# Altium schematic sheet Module.SchDoc(Module)
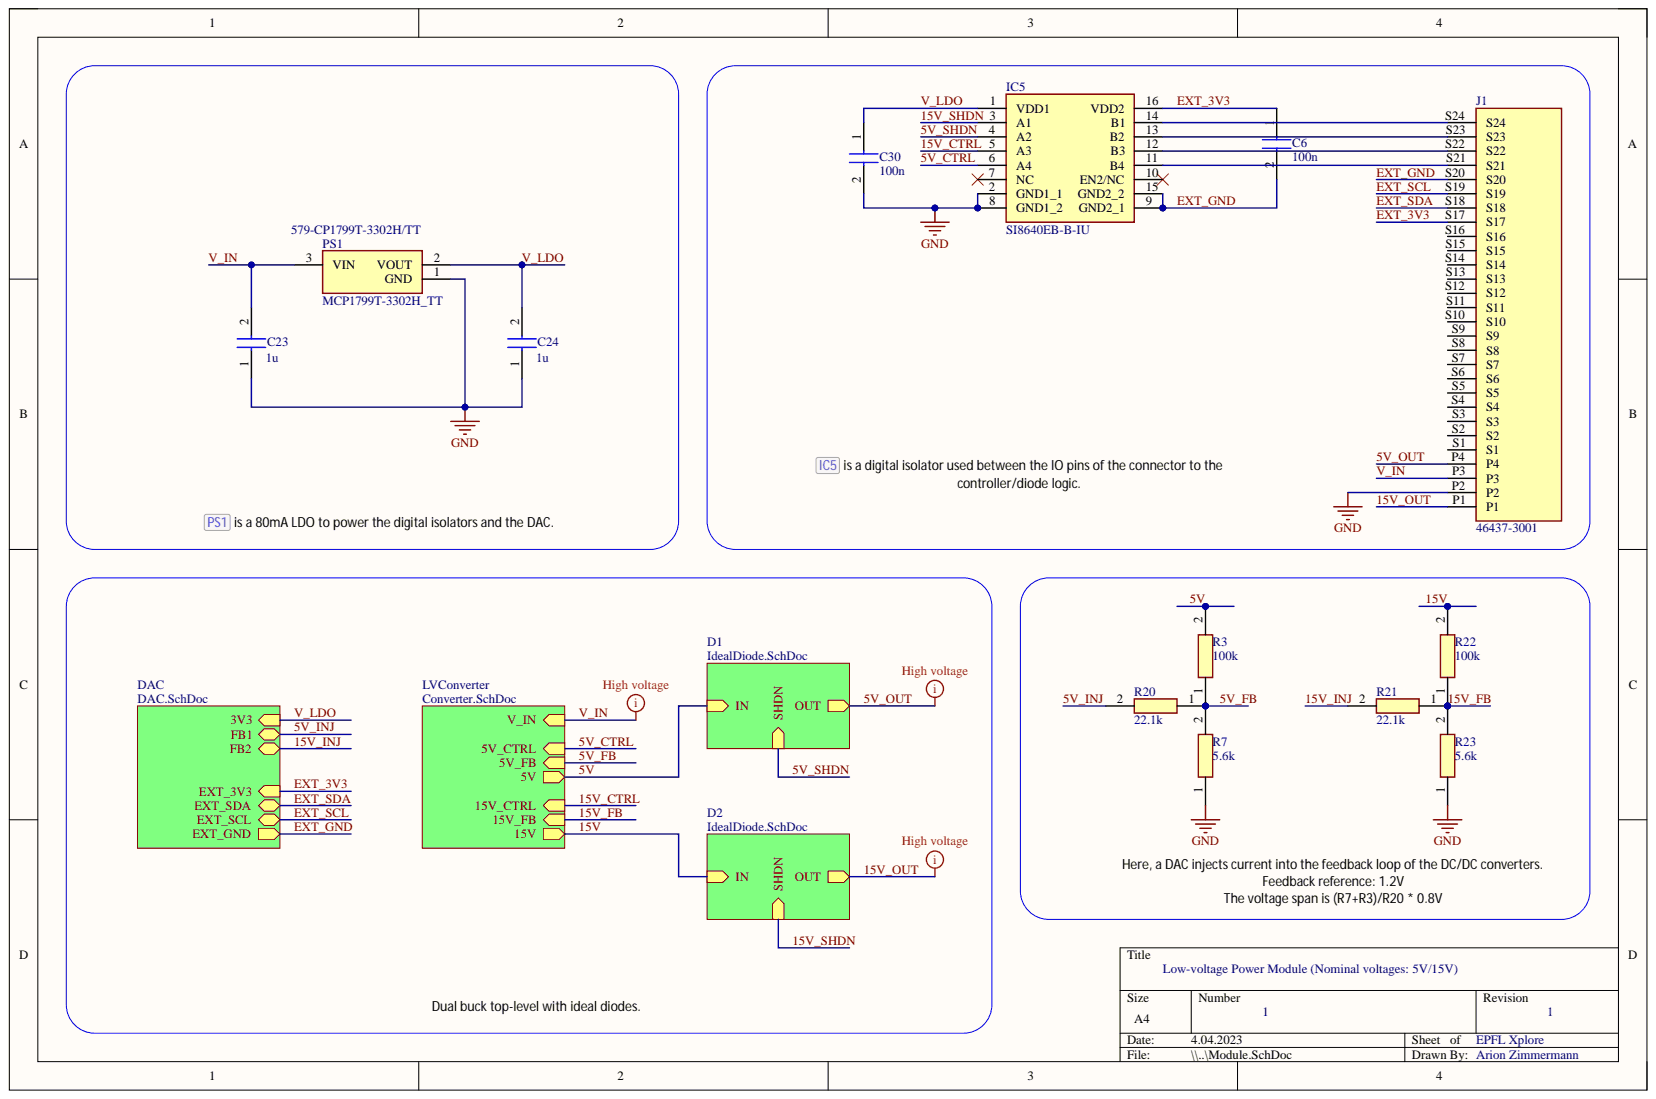
[diagram p0: Module.SchDoc]

## Parts
C6  value 100n  [CAPC1608X95N]  (hidden comment: C0603C104K5RACTU)
C23  value 1u  [CAPC3216X110N]  (hidden comment: C1206C105K5RAC)
C24  value 1u  [CAPC3216X110N]  (hidden comment: C1206C105K5RAC)
C30  value 100n  [CAPC1608X95N]  (hidden comment: C0603C104K5RACTU)
IC5  SI8640EB-B-IU  [SOP64P600X175-16N]
J1  46437-3001  [464373001]
PS1  MCP1799T-3302H_TT  Mouser Part Number: 579-CP1799T-3302H/TT  [SOT95P237X112-3N]
R3  value 100k  [RESC1608X55N]  (hidden comment: CRCW0603100KJNEAC)
R7  value 5.6k  [RESC1608X50N]  (hidden comment: CRCW06035K60JNEAC)
R20  value 22.1k  [RESC1608X50N]  (hidden comment: CRCW060322K1FKEB)
R21  value 22.1k  [RESC1608X50N]  (hidden comment: CRCW060322K1FKEB)
R22  value 100k  [RESC1608X55N]  (hidden comment: CRCW0603100KJNEAC)
R23  value 5.6k  [RESC1608X50N]  (hidden comment: CRCW06035K60JNEAC)

## Nets
GND: C23.1 C24.1 C30.2 IC5.2(GND1_1) IC5.8(GND1_2) J1.P2 PS1.1 R7.1 R23.1
5V: R3.2
5V_CTRL: IC5.6(A4)
5V_FB: R3.1 R7.2 R20.1
5V_INJ: R20.2
5V_OUT: J1.P4
5V_SHDN: IC5.4(A2)
15V: R22.2
15V_CTRL: IC5.5(A3)
15V_FB: R21.1 R22.1 R23.2
15V_INJ: R21.2
15V_OUT: J1.P1
15V_SHDN: IC5.3(A1)
EXT_3V3: C6.1 IC5.16(VDD2) J1.S17
EXT_GND: C6.2 IC5.9(GND2_1) IC5.15(GND2_2) J1.S20
EXT_SCL: J1.S19
EXT_SDA: J1.S18
V_IN: C23.2 J1.P3 PS1.3
V_LDO: C24.2 C30.1 IC5.1(VDD1) PS1.2
NetIC5_7: IC5.7(NC)
NetIC5_10: IC5.10(EN2/NC)
NetIC5_11: IC5.11(B4) J1.S21
NetIC5_12: IC5.12(B3) J1.S22
NetIC5_13: IC5.13(B2) J1.S23
NetIC5_14: IC5.14(B1) J1.S24
NetJ1_S1: J1.S1
NetJ1_S2: J1.S2
NetJ1_S3: J1.S3
NetJ1_S4: J1.S4
NetJ1_S5: J1.S5
NetJ1_S6: J1.S6
NetJ1_S7: J1.S7
NetJ1_S8: J1.S8
NetJ1_S9: J1.S9
NetJ1_S10: J1.S10
NetJ1_S11: J1.S11
NetJ1_S12: J1.S12
NetJ1_S13: J1.S13
NetJ1_S14: J1.S14
NetJ1_S15: J1.S15
NetJ1_S16: J1.S16

## Library data of the parts
C6 C30 = C0603C104K5RACTU: Capacitor; Manufacturer_Name: Kemet; Arrow Part Number: C0603C104K5RACTU; Arrow Price/Stock: https://www.arrow.com/en/products/c0603c104k5ractu/kemet-corporation; Component Kind: Standard; Datasheet Link: http://www.farnell.com/datasheets/2205446.pdf; Height: 0.95mm; Manufacturer_Part_Number: C0603C104K5RACTU; Mouser Part Number: 80-C0603C104K5R; Mouser Price/Stock: https://www.mouser.co.uk/ProductDetail/KEMET/C0603C104K5RACTU?qs=l5k%252BbMnNDkkVcnZPSAaaiQ%3D%3D; Supplier 1: Mouser; Supplier Part Number 1: 80-C0603C104K5R
C23 C24 = C1206C105K5RAC: Capacitor; Manufacturer_Name: KEMET; Arrow Part Number: C1206C105K5RAC; Arrow Price/Stock: https://www.arrow.com/en/products/c1206c105k5rac/kemet-corporation; Component Kind: Standard; Datasheet Link: https://www.kemet.com/specsheet/C1206C105K5RACTU; Height: 1.1mm; Manufacturer_Part_Number: C1206C105K5RAC; Mouser Part Number: 80-C1206C105K5RAC; Mouser Price/Stock: https://www.mouser.co.uk/ProductDetail/KEMET/C1206C105K5RAC?qs=NTAB53G5bVDYFBtoIe7UwQ%3D%3D
IC5 = SI8640EB-B-IU: Integrated Circuit; Manufacturer_Name: Skyworks; Component Kind: Standard; Datasheet Link: https://www.mouser.in/datasheet/2/472/si864x_datasheet-2507790.pdf; Height: 1.75mm; Manufacturer_Part_Number: SI8640EB-B-IU; Mouser Part Number: 634-SI8640EB-B-IU; Mouser Price/Stock: https://www.mouser.co.uk/ProductDetail/Skyworks-Solutions-Inc/SI8640EB-B-IU?qs=1mbolxNpo8djAX8%252BwHCeQQ%3D%3D
J1 = 46437-3001: Connector; Manufacturer_Name: Molex; Component Kind: Standard; Datasheet Link: https://componentsearchengine.com/Datasheets/1/46437-3007.pdf; Height: 10mm; Library Name: SamacSys.SchLib; Manufacturer_Part_Number: 46437-3001; Mouser Part Number: 538-46437-3001; Mouser Price/Stock: https://www.mouser.com/Search/Refine.aspx?Keyword=538-46437-3007; System: Connector
PS1 = MCP1799T-3302H_TT: Power Supply; Manufacturer_Name: Microchip; Manufacturer_Part_Number: MCP1799T-3302H/TT; Arrow Part Number: MCP1799T-3302H/TT; Arrow Price/Stock: https://www.arrow.com/en/products/mcp1799t-3302htt/microchip-technology?region=nac; Component Kind: Standard; Datasheet Link: https://ms.componentsearchengine.com/Datasheets/1/MCP1799T-3302H_TT.pdf; Height: 1.12mm; Mouser Price/Stock: https://www.mouser.co.uk/ProductDetail/Microchip-Technology-Atmel/MCP1799T-3302H-TT?qs=BJlw7L4Cy78EAGTR6Iuibw%3D%3D; Supplier 1: Mouser; Supplier Part Number 1: 579-CP1799T-3302H/TT
R3 R22 = CRCW0603100KFKEAC: Resistor; Manufacturer_Name: Vishay; Arrow Part Number: CRCW0603100KFKEAC; Arrow Price/Stock: https://www.arrow.com/en/products/crcw0603100kfkeac/vishay; Component Kind: Standard; Datasheet Link: https://www.mouser.com/datasheet/2/427/crcwce3-1223726.pdf; Height: 0.55mm; Manufacturer_Part_Number: CRCW0603100KFKEAC; Mouser Part Number: 71-CRCW0603100KFKEAC; Mouser Price/Stock: https://www.mouser.co.uk/ProductDetail/Vishay-Dale/CRCW0603100KFKEAC?qs=E3Y5ESvWgWPxzfNYyMgX1w%3D%3D; Supplier 1: Mouser; Supplier Part Number 1: 71-CRCW0603100KJNEAC
R7 R23 = CRCW06035K62FKEB: Resistor; Manufacturer_Name: Vishay; Component Kind: Standard; Datasheet Link: http://www.vishay.com/docs/20035/dcrcwe3.pdf; Height: 0.5mm; Manufacturer_Part_Number: CRCW06035K62FKEB; Mouser Part Number: 71-CRCW06035K62FKEB; Mouser Price/Stock: https://www.mouser.co.uk/ProductDetail/Vishay-Dale/CRCW06035K62FKEB?qs=P6ImCWcZKGBvDktlVJxa7w%3D%3D; Supplier 1: Mouser; Supplier Part Number 1: 71-CRCW06035K60JNEAC
R20 R21 = CRCW06037K50FKEB: Resistor; Manufacturer_Name: Vishay; Component Kind: Standard; Datasheet Link: http://www.vishay.com/docs/20035/dcrcwe3.pdf; Height: 0.5mm; Manufacturer_Part_Number: CRCW06037K50FKEB; Mouser Part Number: 71-CRCW06037K50FKEB; Mouser Price/Stock: https://www.mouser.co.uk/ProductDetail/Vishay-Dale/CRCW06037K50FKEB?qs=2ihuRUavkaTvW2vO4EimMQ%3D%3D; Supplier 1: Mouser; Supplier Part Number 1: 71-CRCW060322K1FKEB
